# Altium schematic sheet lv_AirConditioner_power.SchDoc(lv_AirConditioner_power)
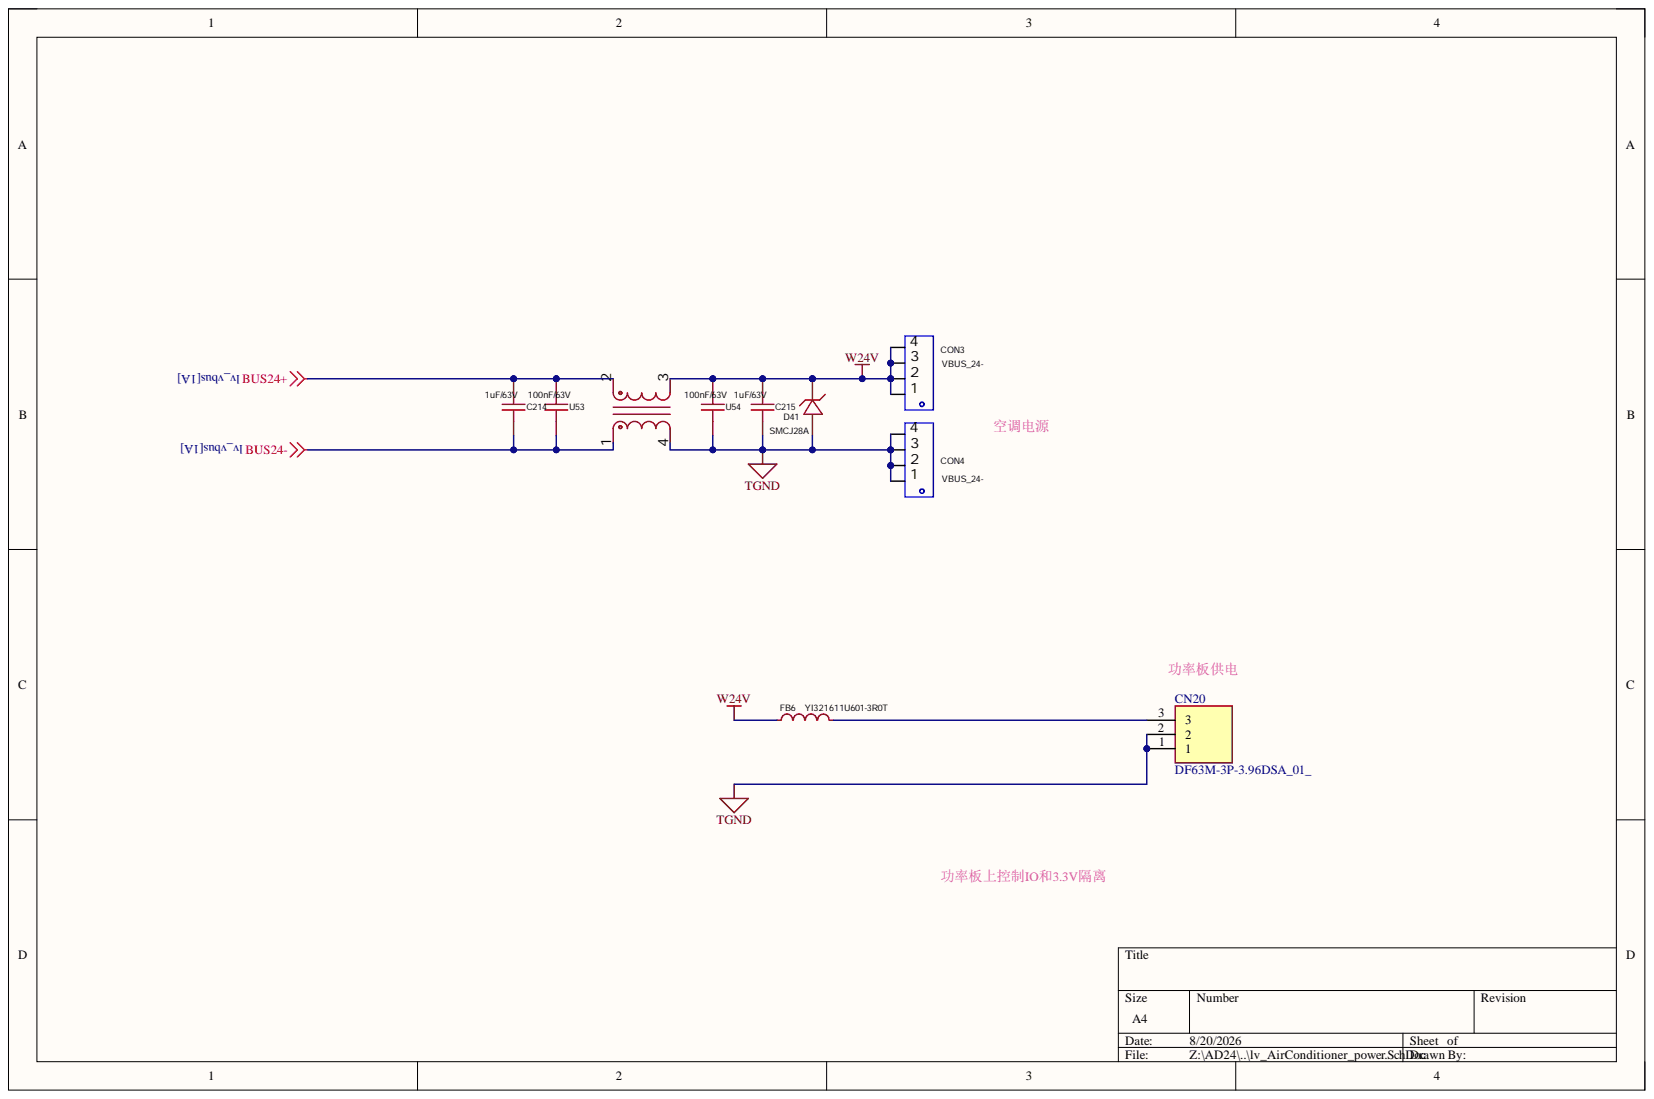
[diagram p0: lv_AirConditioner_power.SchDoc]

## Parts
C214  1uF/63V  [CAP-TH_L7.2-W5.0-P5.00-D1.0]
C215  1uF/63V  [CAP-TH_L7.2-W5.0-P5.00-D1.0]
CN20  DF63M-3P-3.96DSA_01_  [DF63M3P396DSA01]
CON3  VBUS_24-  [CONN-TH_T44001]
CON4  VBUS_24-  [CONN-TH_T44001]
D41  SMCJ28A  [SMC_L6.9-W5.9-LS7.9-RD]
FB6  YI321611U601-3R0T  [L1206]
L31  FC-HLF2018-5mH-25A-LF  [IND-TH_4P-L22.5-W14.5-P13.00-D1.2-LS10.80]  (value not drawn)
U53  100nF/63V  [CAP-TH_L7.2-W2.5-P5.00-D1.0]
U54  100nF/63V  [CAP-TH_L7.2-W2.5-P5.00-D1.0]

## Nets
BUS24+: C214.2 L31.2 U53.2
BUS24-: C214.1 L31.1 U53.1
TGND: C215.1 CN20.1 CN20.2 CON4.1 CON4.2 CON4.3 CON4.4 D41.2 L31.4 U54.1
W24V: C215.2 CON3.1 CON3.2 CON3.3 CON3.4 D41.1 FB6.1 L31.3 U54.2
NetCN20_3: CN20.3 FB6.2

## Library data of the parts
C214 C215 = MEB105J63V82CB0235: 精度±5%,额定电压63V,金属化聚酯; Manufacturer: KNSCHA(科尼盛); Component Kind: Standard; Library Name: HiPin_Library_AD.IntLib; Manufacturer_Part_Number: MEB105J63V82CB0235
CN20 = DF63M-3P-3.96DSA_01_: Connector Header Through Hole 3 position 0.156" (3.96mm); Manufacturer_Name: Hirose; Manufacturer_Part_Number: DF63M-3P-3.96DSA(01); Geometry.Height: 10.3mm; Component Kind: Standard; Datasheet Link: https://www.hirose.com/en/product/document?clcode=CL0680-0568-0-01&productname=DF63M-3P-3.96DSA(01)&series=DF63&documenttype=2DDrawing&lang=en&documentid=0001287261; Library Name: HiPin_Library_AD.IntLib; Mouser Part Number: 798-DF63M3P396DSA01; Mouser Price/Stock: https://www.mouser.co.uk/ProductDetail/Hirose-Connector/DF63M-3P-3.96DSA01?qs=T3oQrply3y%2FNBBEqsbs72w%3D%3D
CON3 CON4 = T44001: 四脚 M4 压接螺丝座; Manufacturer: XFCN(兴飞); Add into BOM: yes; Component Kind: Standard; Convert to PCB: yes; Datasheet: https://atta.szlcsc.com/upload/public/pdf/source/20200107/C481448_4214B4EB74A46B70BD82BA8A456D4EDD.pdf; Device: T44001; JLCPCB Part Class: Extended Part; LCSC Part Name: 四脚 M4 二件套; Library Name: HiPin_Library_AD.IntLib; Manufacturer Part: T44001; Supplier: LCSC; Supplier Footprint: 插件; Supplier Part: C481447; Symbol: T44001
D41 = SMCJ28A_C693618: Manufacturer: FTR(乔光电子); Add into BOM: yes; Breakdown Voltage: 31.1V; Maximum Clamping Voltage: 45.4V; Peak Pulse Current (Ipp)@10/1000us: 33.1A; polarity: 单向; Reverse Stand-Off Voltage (Vrwm): 28V; Type: TVS; Component Kind: Standard; Convert to PCB: yes; Datasheet: https://atta.szlcsc.com/upload/public/pdf/source/20200702/C693547_038122F463C15F3D55BC5385490E6510.pdf; Device: SMCJ28A_C693618; JLCPCB Part Class: Extended Part; LCSC Part Name: SMCJ28A; Library Name: HiPin_Library_AD.IntLib; Manufacturer Part: SMCJ28A; Supplier: LCSC; Supplier Footprint: SMC(DO-214AB); Supplier Part: C693618; Symbol: SMCJ28A_C693618
FB6 = BLM31PG601SN1L: 600Ω@100MHz磁珠，3A，60mΩ，25%; Component Kind: Standard; Library Name: HiPin_Library_AD.IntLib
L31 = FC-HLF2018-5mH-25A-LF: 共模滤波电感; Manufacturer: FC(方成); Add into BOM: yes; Current Rating: 25A; DC Resistance (DCR): 6.5mΩ; Inductance @ Frequency: 5mH; Component Kind: Standard; Convert to PCB: yes; Datasheet: https://atta.szlcsc.com/upload/public/pdf/source/20221207/3992F2C35BDE318E91CE6ED02A57E846.pdf; Device: FC-HLF2018-5mH-25A-LF; Impedance @ Frequency: -; JLCPCB Part Class: Extended Part; LCSC Part Name: 插件共模滤波器; Library Name: HiPin_Library_AD.IntLib; Manufacturer Part: FC-HLF2018-5mH-25A-LF; Number of Lines: -; Operating Temperature: -40℃~+125℃; Supplier: LCSC; Supplier Footprint: 插件; Supplier Part: C5307518; Symbol: FC-HLF2018-5MH-25A-LF; Voltage Rating: -; Voltage Rating - DC: -; Withstanding Voltage: -
U53 U54 = MEB104J63V82CB0236: CBB电容63V, 5%; Manufacturer: KNSCHA(科尼盛); Add into BOM: yes; Dielectric Material: 金属化聚酯; Value: 100nF; Voltage Rated: 63V; Component Kind: Standard; Convert to PCB: yes; Datasheet: https://atta.szlcsc.com/upload/public/pdf/source/20220613/95E40529FEB7A3E68EC83AD5A7304622.pdf; Device: MEB104J63V82CB0236; JLCPCB Part Class: Extended Part; LCSC Part Name: 100nF ±5% 63V; Library Name: HiPin_Library_AD.IntLib; Manufacturer Part: MEB104J63V82CB0236; Operating Temperature: -40℃~+110℃; Supplier: LCSC; Supplier Footprint: 插件,P=5mm; Supplier Part: C3034535; Symbol: MEB104J63V82CB0236; Tolerance: ±5%
